# Altium schematic sheet Main.SchDoc(U_Main)
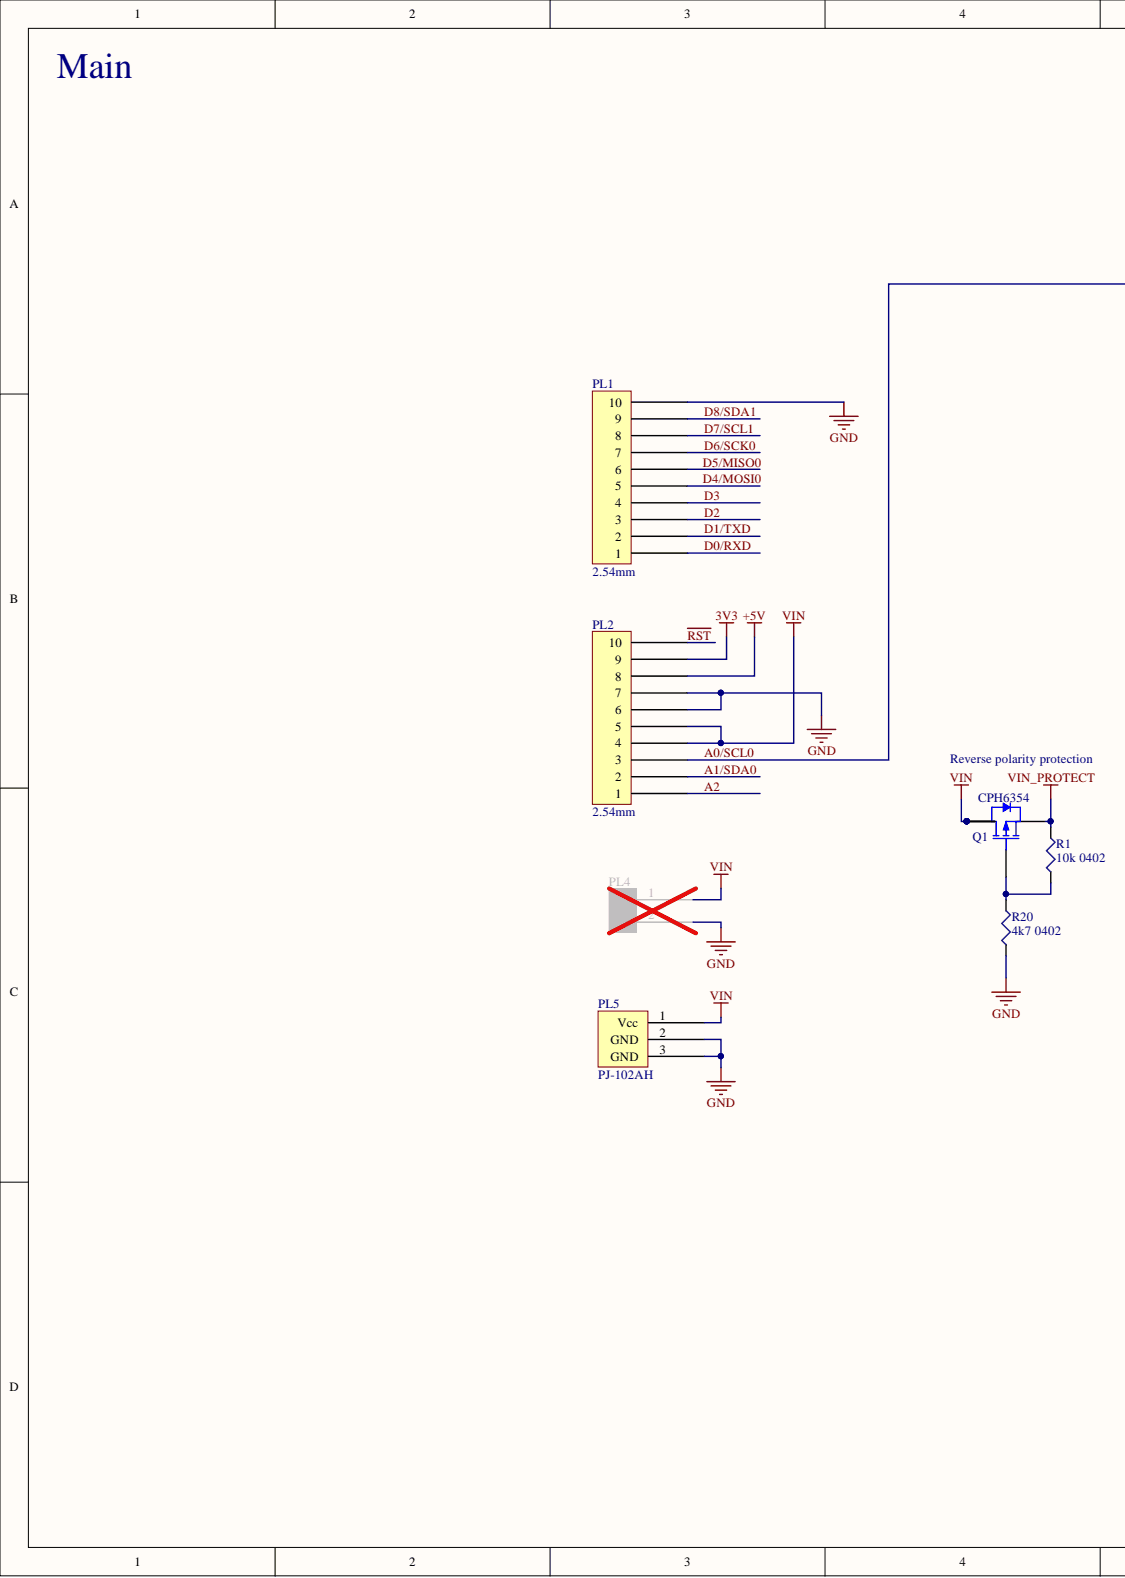
[diagram p0: Main.SchDoc, left]
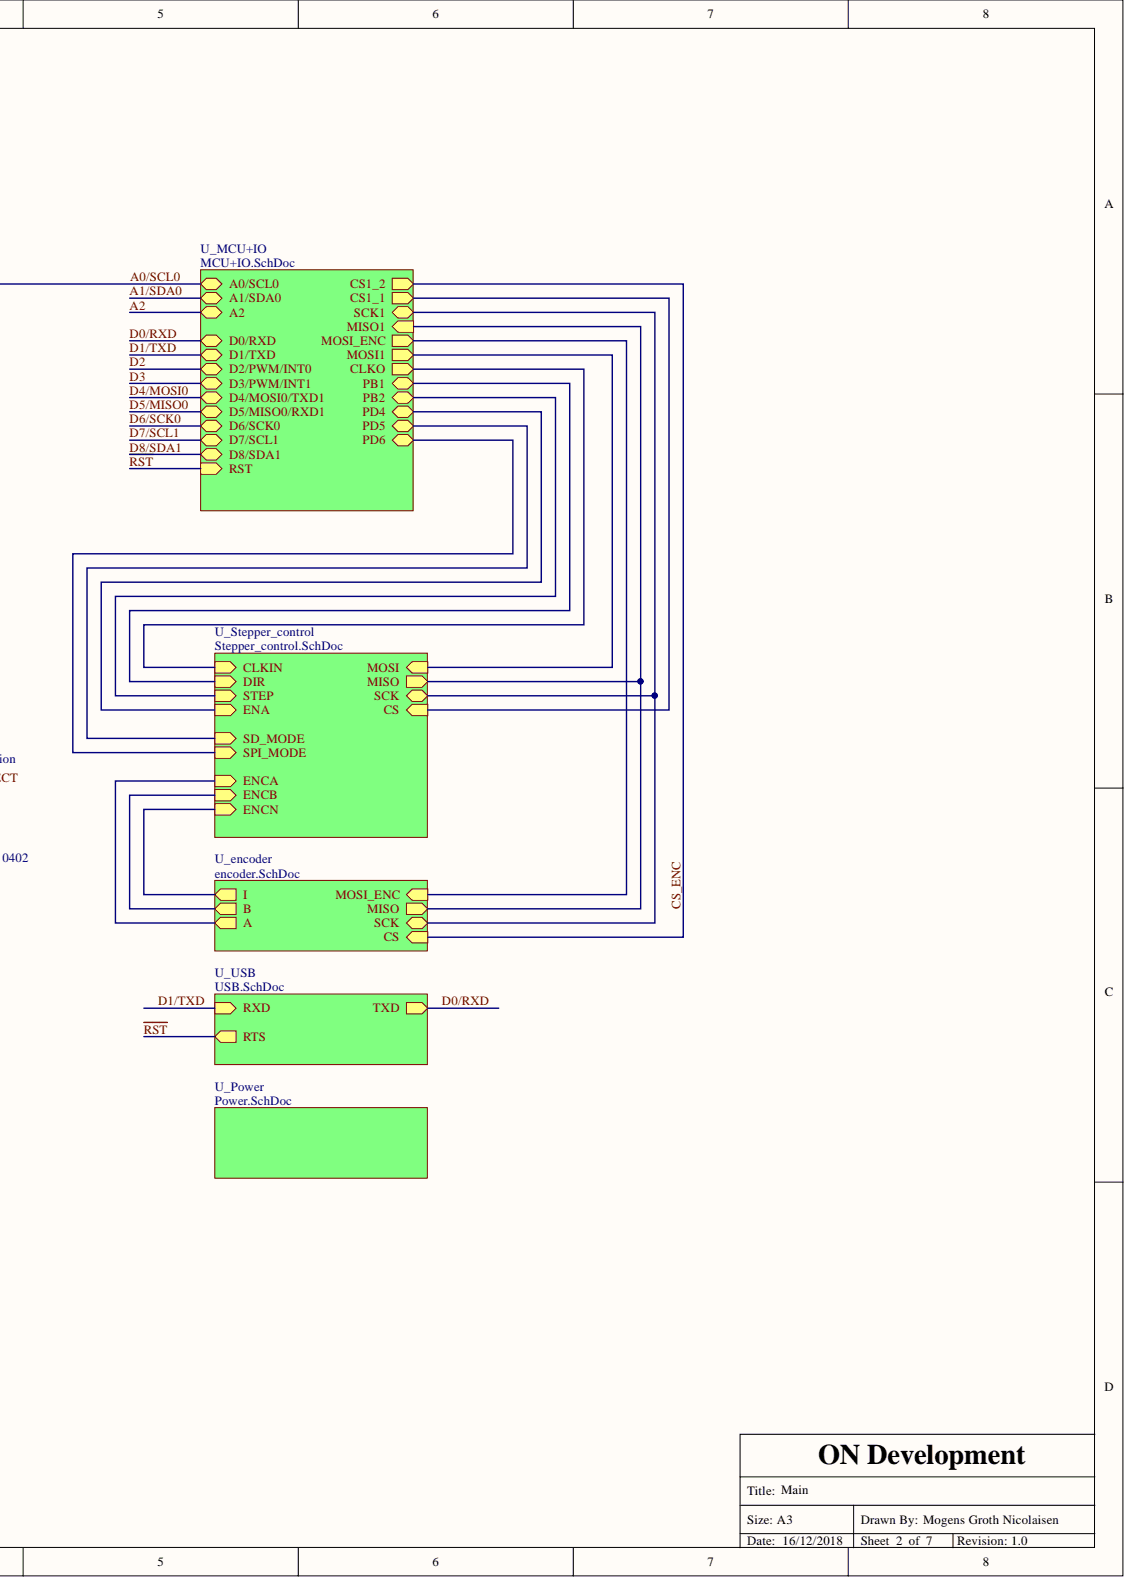
[diagram p1: Main.SchDoc, right]

## Parts
PL1  2.54mm  [10-pin female 2.54mm]
PL2  2.54mm  [10-pin female 2.54mm]
PL4  2pin Terminal block 5.08mm  [5mm header]  (value not drawn)
PL5  PJ-102AH  [PJ-102AH]
Q1  CPH6354  [SOT95P280X95-6N]
R1  10k 0402  value 10k  Case/Package: 0402  [RES_0402]
R20  4k7 0402  value 4k7  Case/Package: 0402  [RES_0402]

## Nets
3V3: PL2.9
+5V: PL2.8
GND: PL1.10 PL2.6 PL2.7 PL4.2 PL5.2 PL5.3 R20.1
VIN: PL2.4 PL2.5 PL4.1 PL5.1 Q1.1 Q1.2 Q1.5 Q1.6
VIN_PROTECT: Q1.4 R1.2
~{RST}: PL2.10
A0/SCL0: PL2.3
A1/SDA0: PL2.2
A2: PL2.1
D0/RXD: PL1.1
D1/TXD: PL1.2
D2: PL1.3
D3: PL1.4
D4/MOSI0: PL1.5
D5/MISO0: PL1.6
D6/SCK0: PL1.7
D7/SCL1: PL1.8
D8/SDA1: PL1.9
NetQ1_3: Q1.3 R1.1 R20.2
A:
B:
CLKO:
CS1_1:
CS_ENC:
I:
MISO:
MOSI1:
MOSI_ENC:
PB1:
PB2:
PD4:
PD5:
PD6:
SCK:

## Library data of the parts
PL1 PL2 = CMP-003-0004-2: Pin header 10-pin 2.54mm female; Mfg. Part Number: DS1023-1*10S21; Library Name: ON Development Vault; Component Kind: Standard
PL4 = CMP-003-0002-2: PCB terminal block, angled 90°; 5mm, 2-way, 1.5mm2; Mfg. Part Number: DG301-5.0-02P-11-00A(H); Library Name: ON Development Vault; Component Kind: Standard
PL5 = CMP-003-0001-3: CONN PWR JACK 2X5.5MM SOLDER; Mfg. Part Number: PJ-102AH; Library Name: ON Development Vault; Component Kind: Standard
Q1 = CMP-014-0006-2: MOSFET P-CH 60V 4A CPH6; Mfg. Part Number: CPH6354-TL-W; Library Name: ON Development Vault; Component Kind: Standard
R1 = CMP-001-0019-3: RES SMD 10K OHM 1% 1/16W 0402; Mfg. Part Number: RMCF0402FT10K0; Tolerance: 1 %; Power: 1/16 W; Library Name: ON Development Vault; Component Kind: Standard
R20 = CMP-001-0029-3: RES SMD 4.7K OHM 1% 1/16W 0402; Mfg. Part Number: RC1005F472CS; Tolerance: 1; Power: 1/16W; Library Name: ON Development Vault; Component Kind: Standard
